# Altium schematic sheet 06_POWER_1.SchDoc(06_POWER_1)
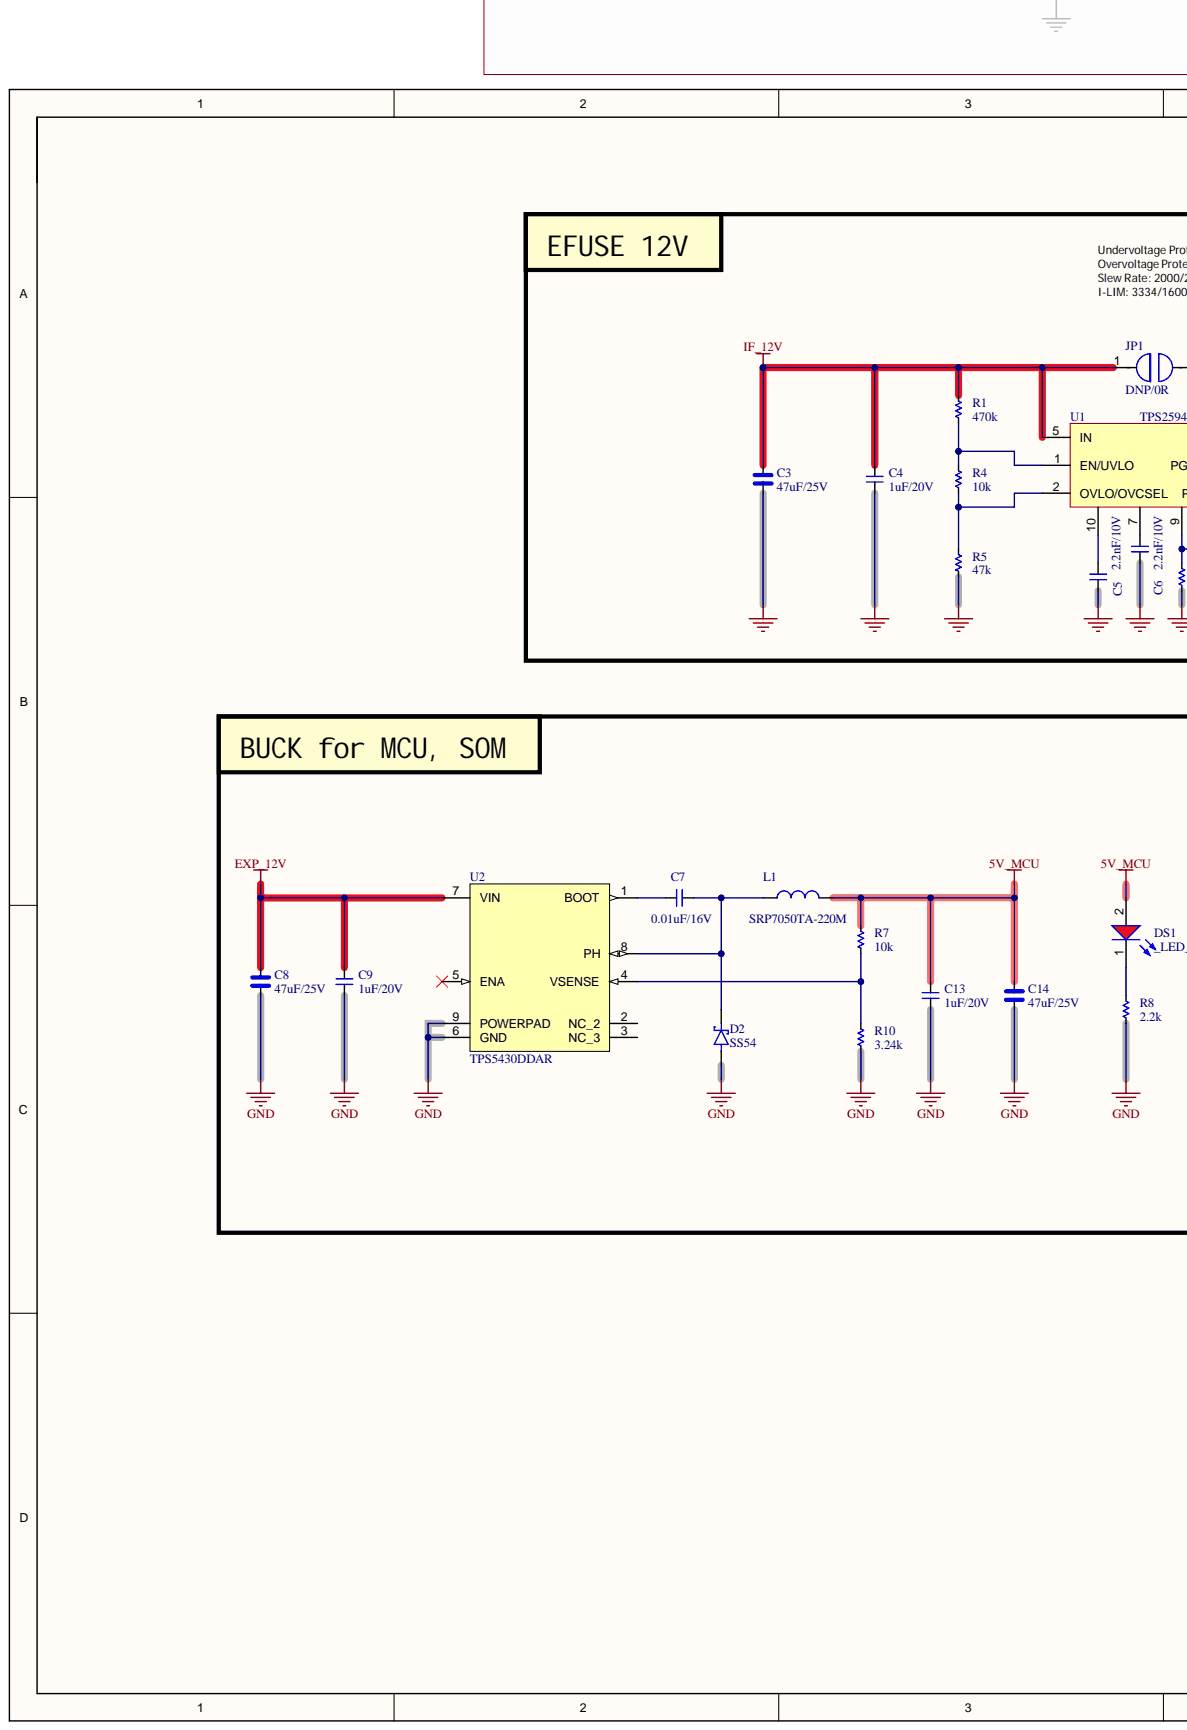
[diagram p0: 06_POWER_1.SchDoc, left]
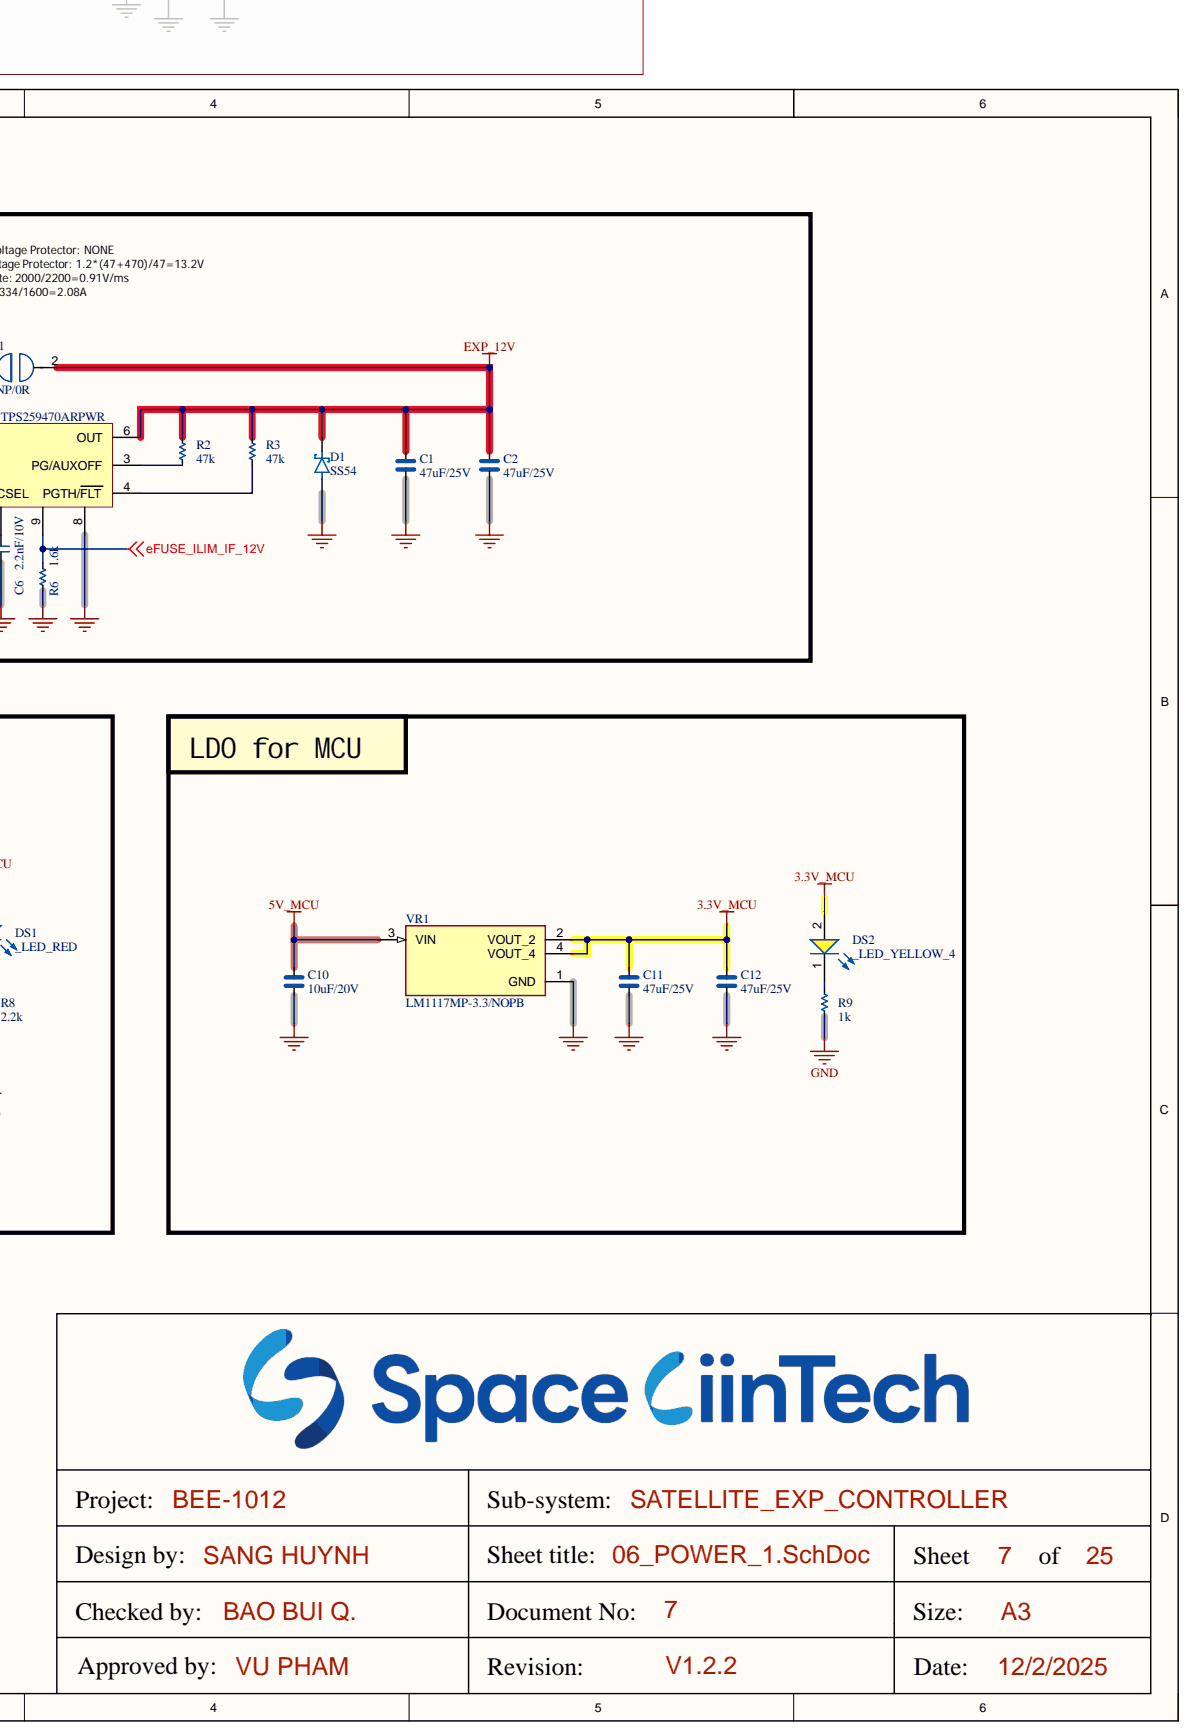
[diagram p1: 06_POWER_1.SchDoc, right]

## Parts
C1  47uF/25V  [CAP_C_3225X80]
C2  47uF/25V  [CAP_C_3225X80]
C3  47uF/25V  [CAP_C_3225X80]
C4  1uF/20V  [CAP_C_1005X60]
C5  2.2nF/10V  [CAP_C_1005X60]
C6  2.2nF/10V  [CAP_C_1005X60]
C7  0.01uF/16V  [CAP_C_1005X60]
C8  47uF/25V  [CAP_C_3225X80]
C9  1uF/20V  [CAP_C_1005X60]
C10  10uF/20V  [CAP_C_2012X110]
C11  47uF/25V  [CAP_C_3225X80]
C12  47uF/25V  [CAP_C_3225X80]
C13  1uF/20V  [CAP_C_1005X60]
C14  47uF/25V  [CAP_C_3225X80]
D1  SS54  [DO-214AC_SMA]
D2  SS54  [DO-214AC_SMA]
DS1  _LED_RED  [LED_0402]
DS2  _LED_YELLOW_4  [LED_0402]
JP1  DNP/0R  [RES_C_1608X60]
L1  SRP7050TA-220M  [PSM6050]
R1  470k  [RES_C_1005X40]
R2  47k  [RES_C_1005X40]
R3  47k  [RES_C_1005X40]
R4  10k  [RES_C_1005X40]
R5  47k  [RES_C_1005X40]
R6  1.6k  [RES_C_1005X40]
R7  10k  [RES_C_1005X40]
R8  2.2k  [RES_C_1005X40]
R9  1k  [RES_C_1005X40]
R10  3.24k  [RES_C_1005X40]
U1  TPS259470ARPWR  [VQFN-HR-10]
U2  TPS5430DDAR  [TPS5430DDAR_V3]
VR1  LM1117MP-3.3/NOPB  [VREG_LM1117MP-3.3/NOPB]

## Nets
3.3V_MCU: C11.2 C12.2 DS2.2 VR1.2(VOUT_2) VR1.4(VOUT_4)
5V_MCU: C10.1 C13.2 C14.2 DS1.2 L1.2 R7.2 VR1.3(VIN)
eFUSE_ILIM_IF_12V: R6.2 U1.9
EXP_12V: C1.2 C2.2 C8.2 C9.2 D1.1 JP1.2 R2.2 R3.2 U1.6(OUT) U2.7(VIN)
GND: C1.1 C2.1 C3.1 C4.1 C5.1 C6.1 C8.1 C9.1 C10.2 C11.1 C12.1 C13.1 C14.1 D1.2 D2.2 R5.1 R6.1 R8.1 R9.1 R10.1 U1.8 U2.6(GND) U2.9(POWERPAD) VR1.1(GND)
IF_12V: C3.2 C4.2 JP1.1 R1.2 U1.5(IN)
NetC5_2: C5.2 U1.10
NetC6_2: C6.2 U1.7
NetC7_1: C7.1 D2.1 L1.1 U2.8(PH)
NetC7_2: C7.2 U2.1(BOOT)
NetDS1_1: DS1.1 R8.2
NetDS2_1: DS2.1 R9.2
NetR1_1: R1.1 R4.1 U1.1(EN/UVLO)
NetR2_1: R2.1 U1.3(PG/AUXOFF)
NetR3_1: R3.1 U1.4(PGTH/FLT)
NetR4_2: R4.2 R5.2 U1.2(OVLO/OVCSEL)
NetR7_1: R7.1 R10.2 U2.4(VSENSE)

Not connected: U2 2(NC_2) 3(NC_3) 5(ENA)

## Library data of the parts
C1-C3 C8 C10-C12 C14 = _CAP_C_High_2: CAP CHIP High Value; Component Kind: Standard; Library Name: LABLIB.SchLib
C4-C7 C9 C13 = _CAP_C_2: CAP CHIP; Component Kind: Standard; Library Name: LABLIB.SchLib
D1 D2 = DIODE SCHOTTKY: Component Kind: Standard; Description: DIODE SCHOTTKY; Library Name: LABLIB.SchLib
JP1 = JUMPER: Component Kind: Standard; Library Name: EXP_V1.SchLib
L1 = SRP7050TA-220M: FIXED IND 22UH 2.5A 170 MOHM SMD; Component Kind: Standard; Library Name: LABLIB.SchLib
R1-R10 = _RES_B_2: RES; Component Kind: Standard; Library Name: LABLIB.SchLib
U2 = TPS5430DDAR: IC REG BUCK ADJ 3A 8SOPWR; Component Kind: Standard; Library Name: EXP_HW_V3.SchLib
VR1 = LM1117MP-3.3/NOPB: 800-mA 15-V linear voltage regulator; MF: Texas Instruments; Check_prices: https://www.snapeda.com/parts/LM1117MP-3.3/NOPB/Texas+Instruments/view-part/?ref=eda; Component Kind: Standard; Library Name: new_component.SchLib; MP: LM1117MP-3.3/NOPB; Package: SOT-223-4 Texas Instruments; SnapEDA_Link: https://www.snapeda.com/parts/LM1117MP-3.3/NOPB/Texas+Instruments/view-part/?ref=snap
DS1 = _LED_RED: Component Kind: Standard; Library Name: LABLIB.SchLib
DS2 = _LED_YELLOW_4: Component Kind: Standard; Library Name: LABLIB.SchLib
U1 = TPS259470ARPWR: Component Kind: Standard; Library Name: EXP_HW_V3.SchLib
